# Altium schematic sheet LR1110_e595v03a_RF.SchDoc(LR1110_e595v03a_RF)
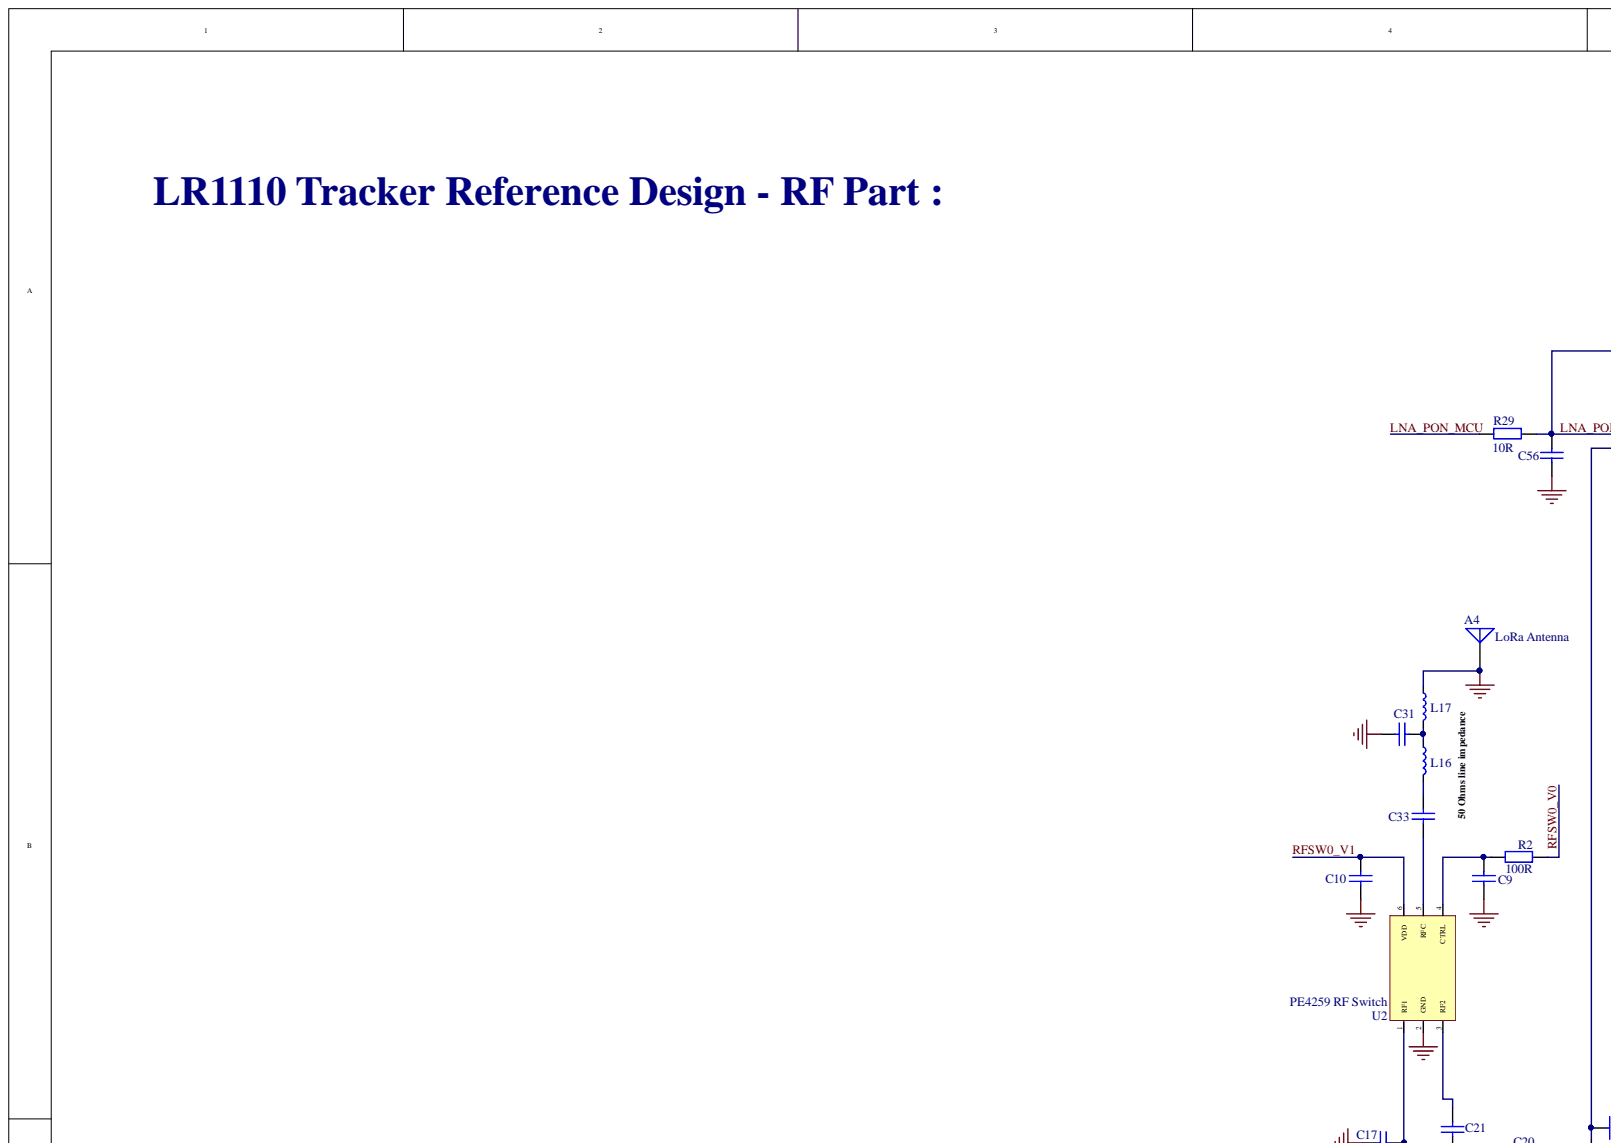
[diagram p0: LR1110_e595v03a_RF.SchDoc, top left]
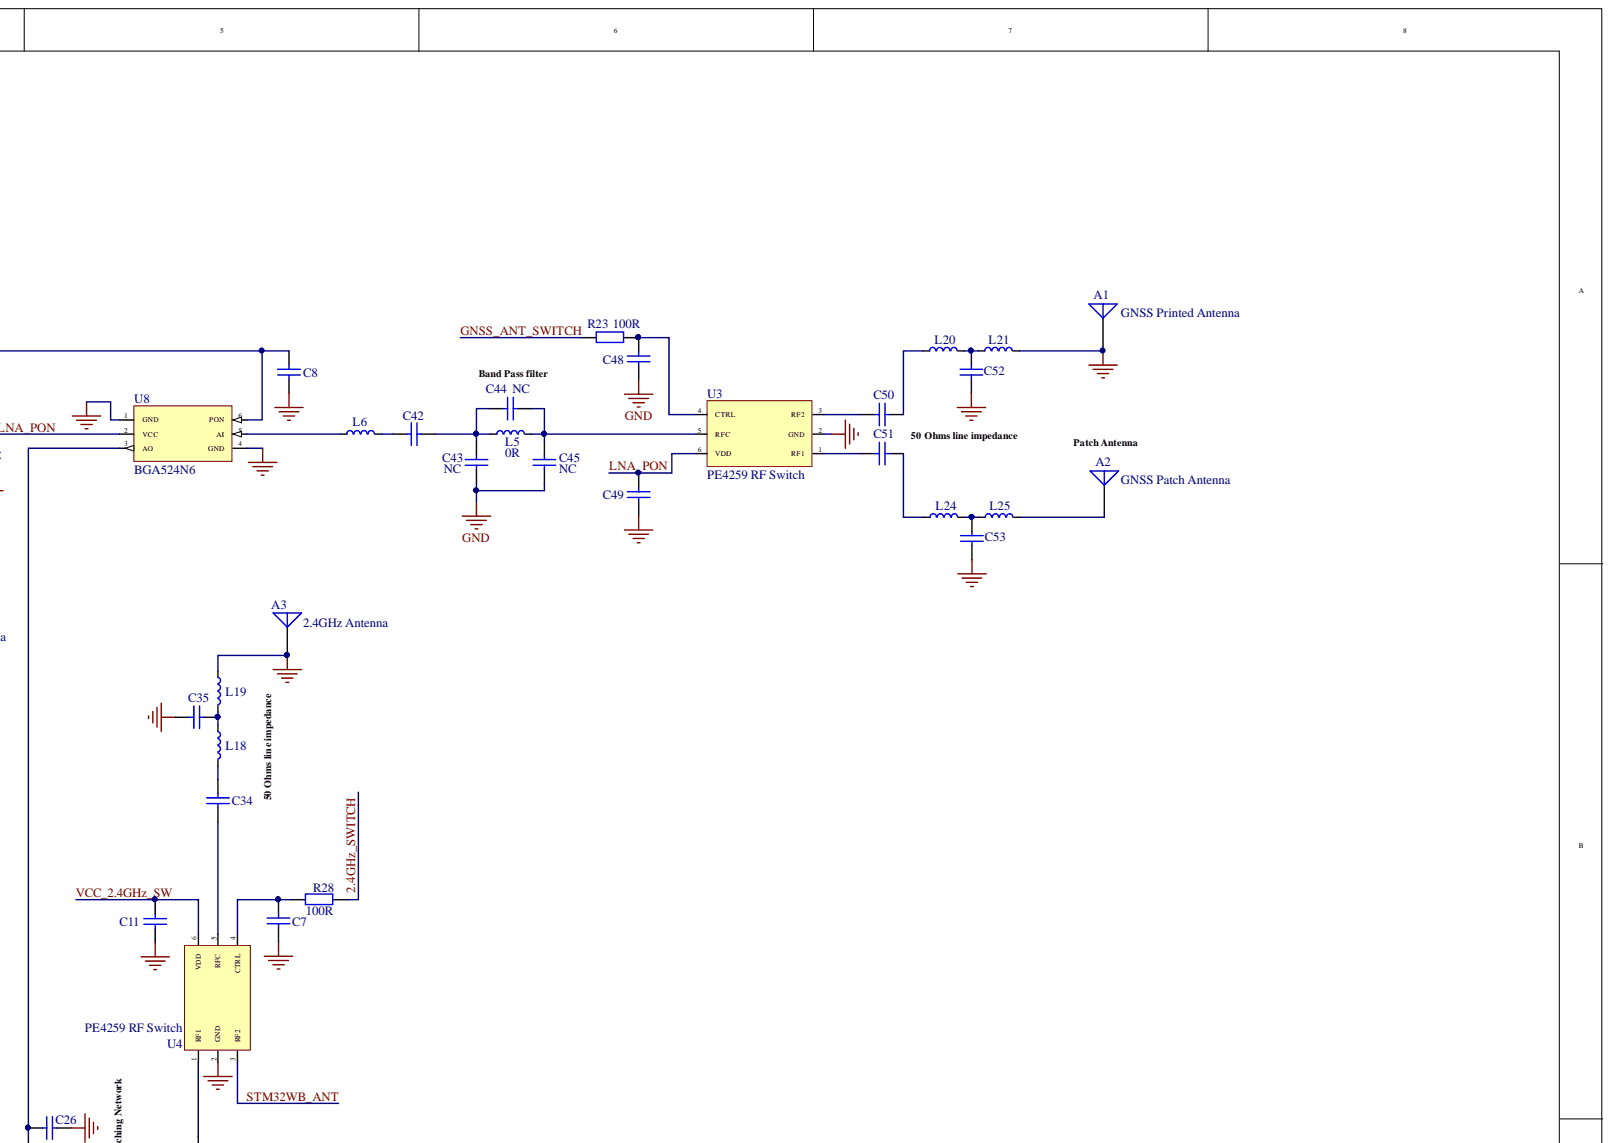
[diagram p1: LR1110_e595v03a_RF.SchDoc, top right]
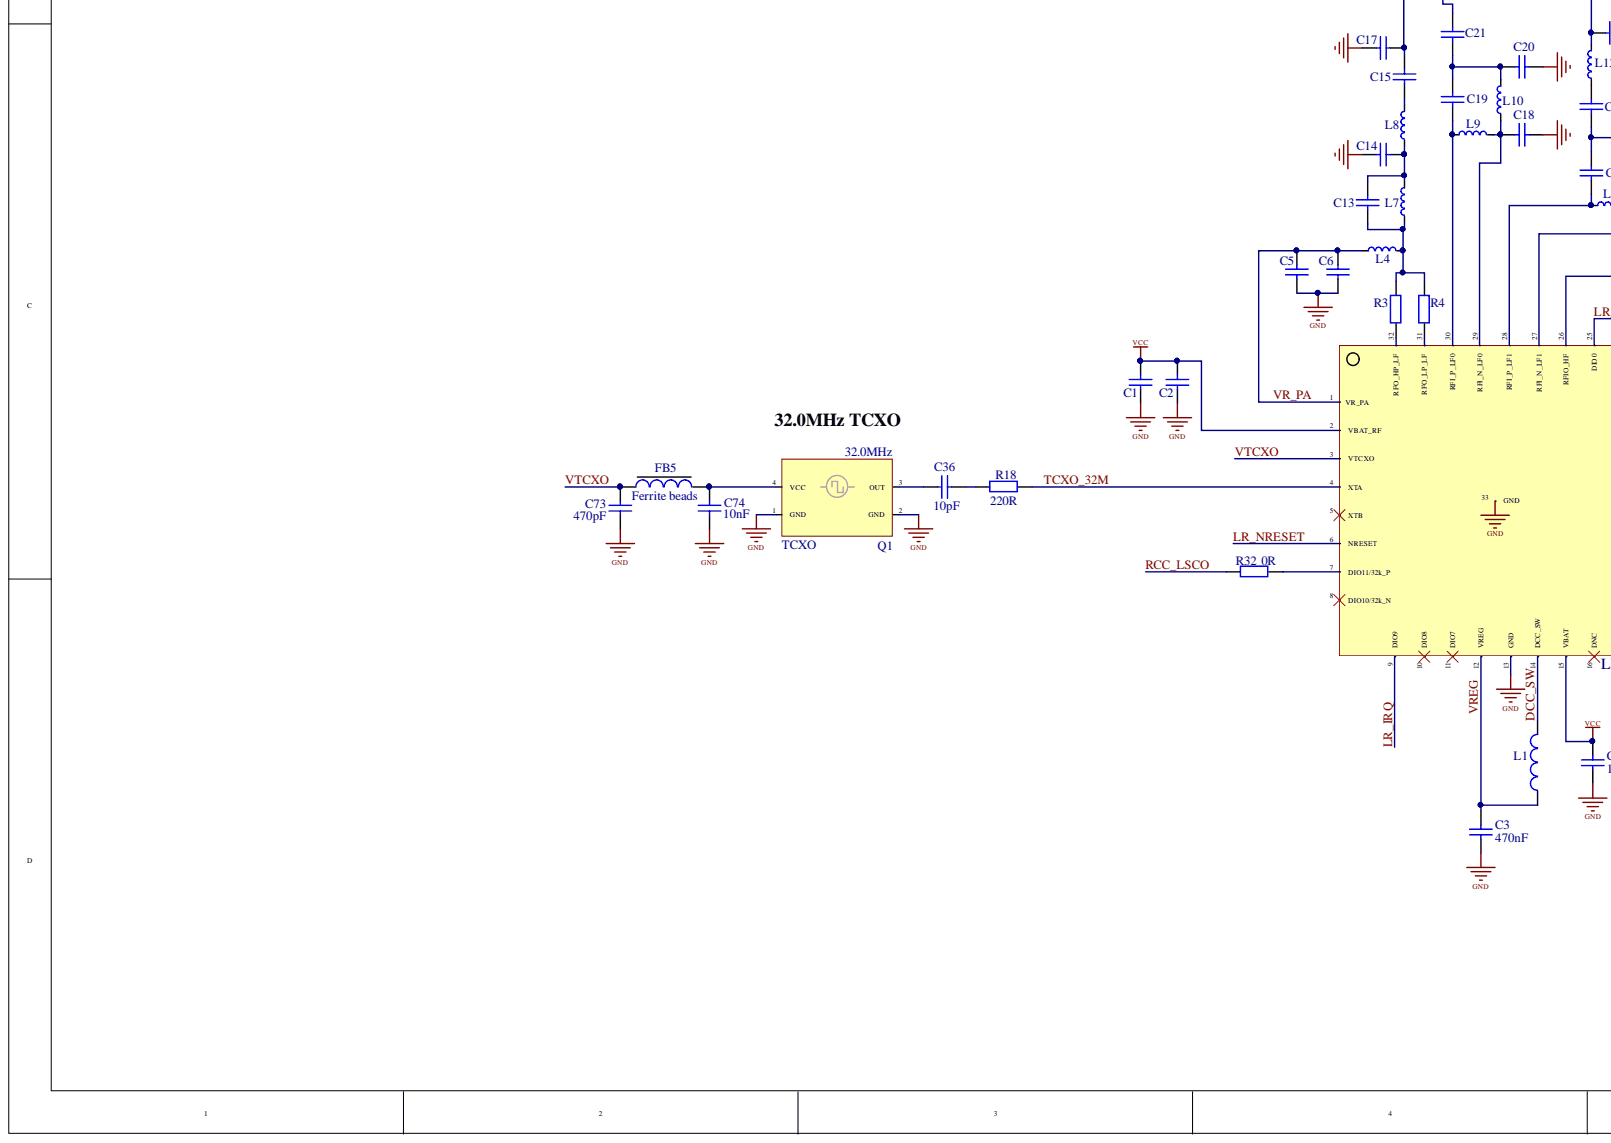
[diagram p2: LR1110_e595v03a_RF.SchDoc, bottom left]
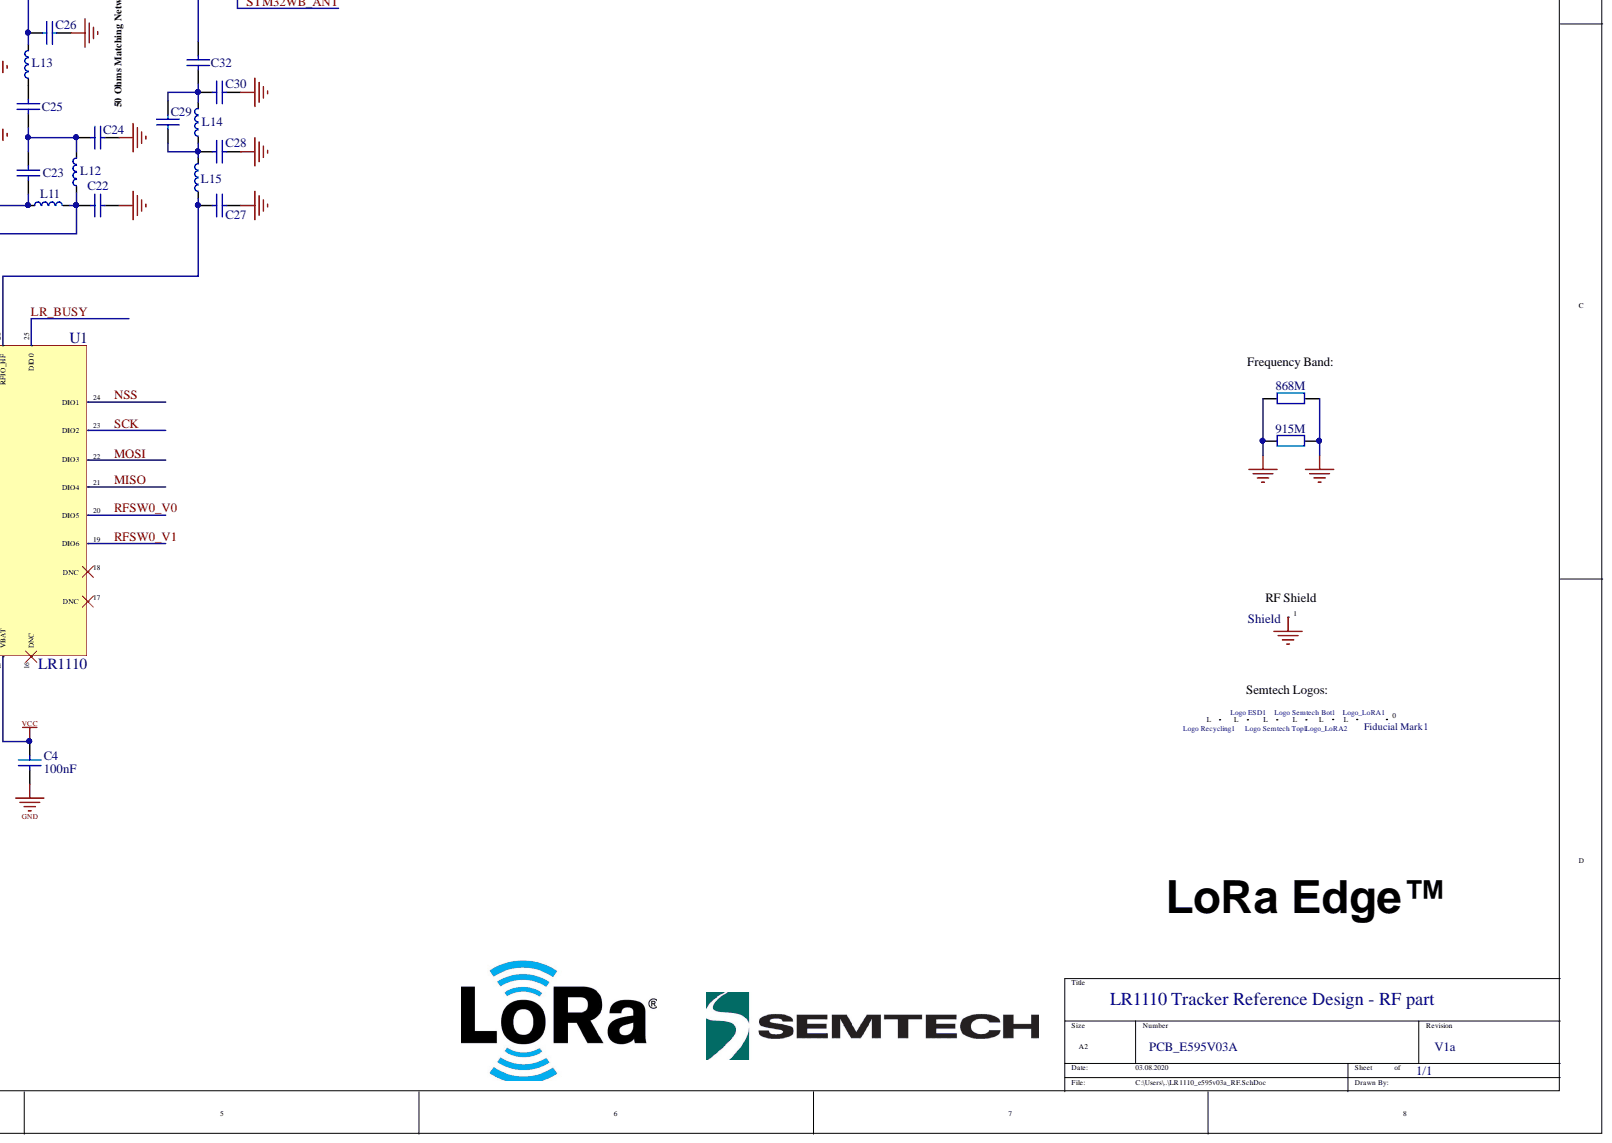
[diagram p3: LR1110_e595v03a_RF.SchDoc, bottom right]

## Parts
868M  Resistor  [rlc_201_smd_small]  (value not drawn)
915M  Resistor  [rlc_201_smd_small]  (value not drawn)
A1  GNSS Printed Antenna  [GNSS antenna tracker]
A2  GNSS Patch Antenna  [GNSS patch antenna 18x18]
A3  2.4GHz Antenna  [Wifi antenna tracker]
A4  LoRa Antenna  [LoRa antenna tracker]
C1  Cap  [rlc_402_smd_small]  (value not drawn)
C2  Cap  [rlc_201_smd_small]  (value not drawn)
C3  value 470nF  [rlc_201_smd_small]  (hidden comment: Cap)
C4  value 100nF  [rlc_201_smd_small]  (hidden comment: Cap)
C5  Cap  [rlc_201_smd_small]  (value not drawn)
C6  Cap  [rlc_201_smd_small]  (value not drawn)
C7  Cap  [rlc_201_smd_small]  (value not drawn)
C8  Cap  [rlc_201_smd_small]  (value not drawn)
C9  Cap  [rlc_201_smd_small]  (value not drawn)
C10  Cap  [rlc_201_smd_small]  (value not drawn)
C11  Cap  [rlc_201_smd_small]  (value not drawn)
C13  Cap  [rlc_201_smd_small]  (value not drawn)
C14  Cap  [rlc_201_smd_small]  (value not drawn)
C15  Cap  [rlc_201_smd_small]  (value not drawn)
C17  Cap  [rlc_201_smd_small]  (value not drawn)
C18  Cap  [rlc_201_smd_small]  (value not drawn)
C19  Cap  [rlc_201_smd_small]  (value not drawn)
C20  Cap  [rlc_201_smd_small]  (value not drawn)
C21  Cap  [rlc_201_smd_small]  (value not drawn)
C22  Cap  [rlc_201_smd_small]  (value not drawn)
C23  Cap  [rlc_201_smd_small]  (value not drawn)
C24  Cap  [rlc_201_smd_small]  (value not drawn)
C25  Cap  [rlc_201_smd_small]  (value not drawn)
C26  Cap  [rlc_201_smd_small]  (value not drawn)
C27  Cap  [rlc_201_smd_small]  (value not drawn)
C28  Cap  [rlc_201_smd_small]  (value not drawn)
C29  Cap  [rlc_201_smd_small]  (value not drawn)
C30  Cap  [rlc_201_smd_small]  (value not drawn)
C31  Cap  [rlc_201_smd_small]  (value not drawn)
C32  Cap  [rlc_201_smd_small]  (value not drawn)
C33  Cap  [rlc_201_smd_small]  (value not drawn)
C34  Cap  [rlc_201_smd_small]  (value not drawn)
C35  Cap  [rlc_201_smd_small]  (value not drawn)
C36  value 10pF  [rlc_201_smd_small]  (hidden comment: Cap)
C42  Cap  [rlc_201_smd_small]  (value not drawn)
C43  value NC  [rlc_201_smd_small]  (hidden comment: Cap)
C44  value NC  [rlc_201_smd_small]  (hidden comment: Cap)
C45  value NC  [rlc_201_smd_small]  (hidden comment: Cap)
C48  Cap  [rlc_201_smd_small]  (value not drawn)
C49  Cap  [rlc_201_smd_small]  (value not drawn)
C50  Cap  [rlc_201_smd_small]  (value not drawn)
C51  Cap  [rlc_201_smd_small]  (value not drawn)
C52  Cap  [rlc_201_smd_small]  (value not drawn)
C53  Cap  [rlc_201_smd_small]  (value not drawn)
C56  Cap  [rlc_201_smd_small]  (value not drawn)
C73  value 470pF  [rlc_201_smd_small]  (hidden comment: Cap)
C74  value 10nF  [rlc_201_smd_small]  (hidden comment: Cap)
FB5  Ferrite beads  [rlc_201_smd_small]
Fiducial_Mark1  Logo symbol  BOT  [fiducial_mark_signal_top]  (value not drawn)
L1  Inductor  [rlc_603_smd_small]  (value not drawn)
L4  Inductor small symbol  [rlc_201_smd_small]  (value not drawn)
L5  value 0R  [rlc_201_smd_small]  (hidden comment: Inductor small symbol)
L6  Inductor small symbol  [rlc_201_smd_small]  (value not drawn)
L7  Inductor small symbol  [rlc_201_smd_small]  (value not drawn)
L8  Inductor small symbol  [rlc_201_smd_small]  (value not drawn)
L9  Inductor small symbol  [rlc_201_smd_small]  (value not drawn)
L10  Inductor small symbol  [rlc_201_smd_small]  (value not drawn)
L11  Inductor small symbol  [rlc_201_smd_small]  (value not drawn)
L12  Inductor small symbol  [rlc_201_smd_small]  (value not drawn)
L13  Inductor small symbol  [rlc_201_smd_small]  (value not drawn)
L14  Inductor small symbol  [rlc_201_smd_small]  (value not drawn)
L15  Inductor small symbol  [rlc_201_smd_small]  (value not drawn)
L16  Inductor small symbol  [rlc_201_smd_small]  (value not drawn)
L17  Inductor small symbol  [rlc_201_smd_small]  (value not drawn)
L18  Inductor small symbol  [rlc_201_smd_small]  (value not drawn)
L19  Inductor small symbol  [rlc_201_smd_small]  (value not drawn)
L20  Inductor small symbol  [rlc_201_smd_small]  (value not drawn)
L21  Inductor small symbol  [rlc_201_smd_small]  (value not drawn)
L24  Inductor small symbol  [rlc_201_smd_small]  (value not drawn)
L25  Inductor small symbol  [rlc_201_smd_small]  (value not drawn)
Logo_ESD1  Logo symbol  [Logo ESD]  (value not drawn)
Logo_Recycling1  Logo symbol  [Logo Recycling1]  (value not drawn)
Logo_Semtech_Bot1  Logo symbol  [logo_semtech_horiz_size2]  (value not drawn)
Logo_Semtech_Top1  Logo symbol  [logo_semtech_horiz_size3]  (value not drawn)
Logo_LoRA1  Logo symbol  [Logo LoRa Size3]  (value not drawn)
Logo_LoRA2  Logo symbol  [Logo LoRa Size2]  (value not drawn)
Q1  TCXO  value 32.0MHz  [TCXO 2.0x1.6mm]
R2  value 100R  [rlc_201_smd_small]  (hidden comment: Resistor)
R3  Resistor  [rlc_201_smd_small]  (value not drawn)
R4  Resistor  [rlc_201_smd_small]  (value not drawn)
R18  value 220R  [rlc_201_smd_small]  (hidden comment: Resistor)
R23  value 100R  [rlc_201_smd_small]  (hidden comment: Resistor)
R28  value 100R  [rlc_201_smd_small]  (hidden comment: Resistor)
R29  value 10R  [rlc_201_smd_small]  (hidden comment: Resistor)
R32  value 0R  [rlc_201_smd_small]  (hidden comment: Resistor)
Shield  RF Shield  [Custom Shield]
U1  LR1110  [qfn32l_5x5mm]
U2  PE4259 RF Switch  [SC70-6]
U3  PE4259 RF Switch  [SC70-6]
U4  PE4259 RF Switch  [SC70-6]
U8  BGA524N6  [TSNP-6-2]

## Nets
GND: 868M.1 868M.2 915M.1 915M.2 A1.1 A3.1 A4.1 C1.1 C2.1 C3.1 C4.1 C5.1 C6.1 C7.2 C8.1 C9.1 C10.1 C11.1 C14.2 C17.2 C18.1 C20.1 C22.1 C24.1 C26.1 C27.1 C28.1 C30.1 C31.1 C35.1 C43.2 C45.2 C48.2 C49.1 C52.1 C53.1 C56.1 C73.1 C74.1 L17.1 L19.1 L21.2 Q1.1(GND) Q1.2(GND) Shield.1 U1.13 U1.33 U2.2(GND) U3.2(GND) U4.2(GND) U8.1(GND) U8.4(GND)
VCC: C1.2 C2.2 C4.2 U1.2(VBAT_RF) U1.15
2.4GHz_SWITCH: R28.1
DCC_SW: L1.2 U1.14
GNSS_ANT_SWITCH: R23.2
LNA_PON: C8.2 C49.2 C56.2 R29.2 U3.6(VDD) U8.2(VCC) U8.6(PON)
LNA_PON_MCU: R29.1
LR_BUSY: U1.25
LR_IRQ: U1.9
LR_NRESET: U1.6(NRESET)
MISO: U1.21
MOSI: U1.22
NSS: U1.24
RCC_LSCO: R32.2
RFSW0_V0: R2.2 U1.20
RFSW0_V1: C10.2 U1.19 U2.6(VDD)
SCK: U1.23
STM32WB_ANT: U4.3(RF2)
TCXO_32M: R18.1 U1.4(XTA)
VCC_2.4GHz_SW: C11.2 U4.6(VDD)
VR_PA: C5.2 C6.2 L4.1 U1.1
VREG: C3.2 L1.1 U1.12
VTCXO: C73.2 FB5.1 U1.3(VTCXO)
NetA2_1: A2.1 L25.2
NetC7_1: C7.1 R28.2 U4.4(CTRL)
NetC9_2: C9.2 R2.1 U2.4(CTRL)
NetC13_1: C13.1 L4.2 L7.1 R3.1 R4.1
NetC13_2: C13.2 C14.1 L7.2 L8.1
NetC15_1: C15.1 L8.2
NetC15_2: C15.2 C17.1 U2.1(RF1)
NetC18_2: C18.2 L9.2 L10.1 U1.29
NetC19_1: C19.1 L9.1 U1.30
NetC19_2: C19.2 C20.2 C21.2 L10.2
NetC21_1: C21.1 U2.3(RF2)
NetC22_2: C22.2 L11.2 L12.1 U1.27
NetC23_1: C23.1 L11.1 U1.28
NetC23_2: C23.2 C24.2 C25.2 L12.2
NetC25_1: C25.1 L13.1
NetC26_2: C26.2 L13.2 U8.3(AO)
NetC27_2: C27.2 L15.1 U1.26
NetC28_2: C28.2 C29.1 L14.1 L15.2
NetC29_2: C29.2 C30.2 C32.1 L14.2
NetC31_2: C31.2 L16.1 L17.2
NetC32_2: C32.2 U4.1(RF1)
NetC33_1: C33.1 L16.2
NetC33_2: C33.2 U2.5(RFC)
NetC34_1: C34.1 L18.2
NetC34_2: C34.2 U4.5(RFC)
NetC35_2: C35.2 L18.1 L19.2
NetC36_1: C36.1 Q1.3(OUT)
NetC36_2: C36.2 R18.2
NetC42_1: C42.1 L6.2
NetC42_2: C42.2 C43.1 C44.1 L5.1
NetC44_2: C44.2 C45.1 L5.2 U3.5(RFC)
NetC48_1: C48.1 R23.1 U3.4(CTRL)
NetC50_1: C50.1 L20.1
NetC50_2: C50.2 U3.3(RF2)
NetC51_1: C51.1 L24.1
NetC51_2: C51.2 U3.1(RF1)
NetC52_2: C52.2 L20.2 L21.1
NetC53_2: C53.2 L24.2 L25.1
NetC74_2: C74.2 FB5.2 Q1.4(VCC)
NetL6_1: L6.1 U8.5(AI)
NetR3_2: R3.2 U1.32
NetR4_2: R4.2 U1.31
NetR32_1: R32.1 U1.7(DIO11/32k_P)

Not connected: Fiducial_Mark1 0; Logo_ESD1 0; Logo_Recycling1 0; Logo_Semtech_Bot1 0; Logo_Semtech_Top1 0; Logo_LoRA1 0; Logo_LoRA2 0; U1 5(XTB) 8 10 11 16 17 18

## Library data of the parts
868M 915M R3 R4 = Resistor: Resistor SMD - IEC symbol version; Value: 0R; Library Name: LR1110_e595v03a.SchLib; LatestRevisionDate: 27-Jul-20  -  Semtech; Component Kind: Standard
A1 A3 A4 = Antenna: Generic Antenna; Published: 24-Mar-1999; PackageReference: PIN1; Library Name: LR1110_e595v03a.SchLib; LatestRevisionNote: Re-released for DXP Platform.; LatestRevisionDate: 17-Jul-2002; Component Kind: Standard; Publisher: Altium Limited
A2 = Taoglas GP.1575.25.2: 2.4GHz Mini Patch Antenna, RHCP, Dual-feed, SMD; Library Name: LR1110_e595v03a.SchLib; LatestRevisionDate: 05-oct-2007  -  Semtech; Component Kind: Standard
C1 C5 C6 C8-C11 C13-C15 C17-C35 C49-C53 = Cap: Capacitor SMD; Value: 1uF; Simulation: CAP; Signal Integrity: Cap Semi; Published: 8-Jun-2000; Library Name: LR1110_e595v03a.SchLib; LatestRevisionNote: Re-released for DXP Platform.; LatestRevisionDate: 05-oct-2007  -  Semtech; Component Kind: Standard; Publisher: Altium Limited
C2 = Cap: Capacitor SMD; Value: 47pF; Simulation: CAP; Signal Integrity: Cap Semi; Published: 8-Jun-2000; Library Name: LR1110_e595v03a.SchLib; LatestRevisionNote: Re-released for DXP Platform.; LatestRevisionDate: 05-oct-2007  -  Semtech; Component Kind: Standard; Publisher: Altium Limited
C3 C4 C7 C36 C42-C45 C56 C73 C74 = Cap: Capacitor SMD; Simulation: CAP; Signal Integrity: Cap Semi; Published: 8-Jun-2000; Library Name: LR1110_e595v03a.SchLib; LatestRevisionNote: Re-released for DXP Platform.; LatestRevisionDate: 05-oct-2007  -  Semtech; Component Kind: Standard; Publisher: Altium Limited
  C7 C42 C56: Value: ?
C48 = Cap: Capacitor SMD; Value: 1nF; Simulation: CAP; Signal Integrity: Cap Semi; Published: 8-Jun-2000; Library Name: LR1110_e595v03a.SchLib; LatestRevisionNote: Re-released for DXP Platform.; LatestRevisionDate: 05-oct-2007  -  Semtech; Component Kind: Standard; Publisher: Altium Limited
FB5 = Ferrite beads: Value: VAL?; Library Name: LR1110_e595v03a.SchLib; Description: Ferrite beads; Component Kind: Standard
Fiducial_Mark1 Logo_ESD1 Logo_LoRA1 Logo_LoRA2 Logo_Recycling1 Logo_Semtech_Bot1 Logo_Semtech_Top1 = Logo: Schematic symbol for Logo; Library Name: LR1110_e595v03a.SchLib; Component Kind: Standard
L1 = Inductor: Value: 10uH; Code_IEC: 1005; Published: 8-Jun-2000; Library Name: LR1110_e595v03a.SchLib; LatestRevisionNote: Re-released for DXP Platform.; LatestRevisionDate: 05-oct-2007  -  Semtech; Description: Inductor; Component Kind: Standard; Code_JEITA: 0402; Publisher: Altium Limited
L4 L6-L21 L24 L25 = Inductor_small: Inductor; Value: VAL?; Code_IEC: 1005; Published: 8-Jun-2000; Library Name: LR1110_e595v03a.SchLib; LatestRevisionNote: Re-released for DXP Platform.; LatestRevisionDate: 05-oct-2007  -  Semtech; Component Kind: Standard; Code_JEITA: 0402; Publisher: Altium Limited
L5 = Inductor_small: Inductor; Code_IEC: 1005; Published: 8-Jun-2000; Library Name: LR1110_e595v03a.SchLib; LatestRevisionNote: Re-released for DXP Platform.; LatestRevisionDate: 05-oct-2007  -  Semtech; Component Kind: Standard; Code_JEITA: 0402; Publisher: Altium Limited
R2 R18 R23 R28 R29 R32 = Resistor: Resistor SMD - IEC symbol version; Library Name: LR1110_e595v03a.SchLib; LatestRevisionDate: 27-Jul-20  -  Semtech; Component Kind: Standard
Shield = Shield: RF Shield - EMI Gasket - Standard Surface Mount Shields; Library Name: LR1110_e595v03a.SchLib; Component Kind: Standard
U1 = LR1110: LR1110 - Low Power Integrated UHF Transceiver with LoRa Modem; Library Name: LR1110_e595v03a.SchLib; LatestRevisionDate: 27-Jul-2009 -  Semtech; Component Kind: Standard
U2-U4 = PE4259 RF Switch: PE4259 Peregrine RF Switch; Library Name: LR1110_e595v03a.SchLib; LatestRevisionDate: 03-Sep-2012 -  Semtech; Component Kind: Standard
U8 = BGA524N6: Silicon Germanium Low Noise Amplifier; Library Name: LR1110_e595v03a.SchLib; Component Kind: Standard
Q1 = TCXO: Library Name: LR1110_e595v03a.SchLib; Description: TCXO; Component Kind: Standard
